# Altium schematic sheet [03] - IMU.SchDoc("[03] - IMU")
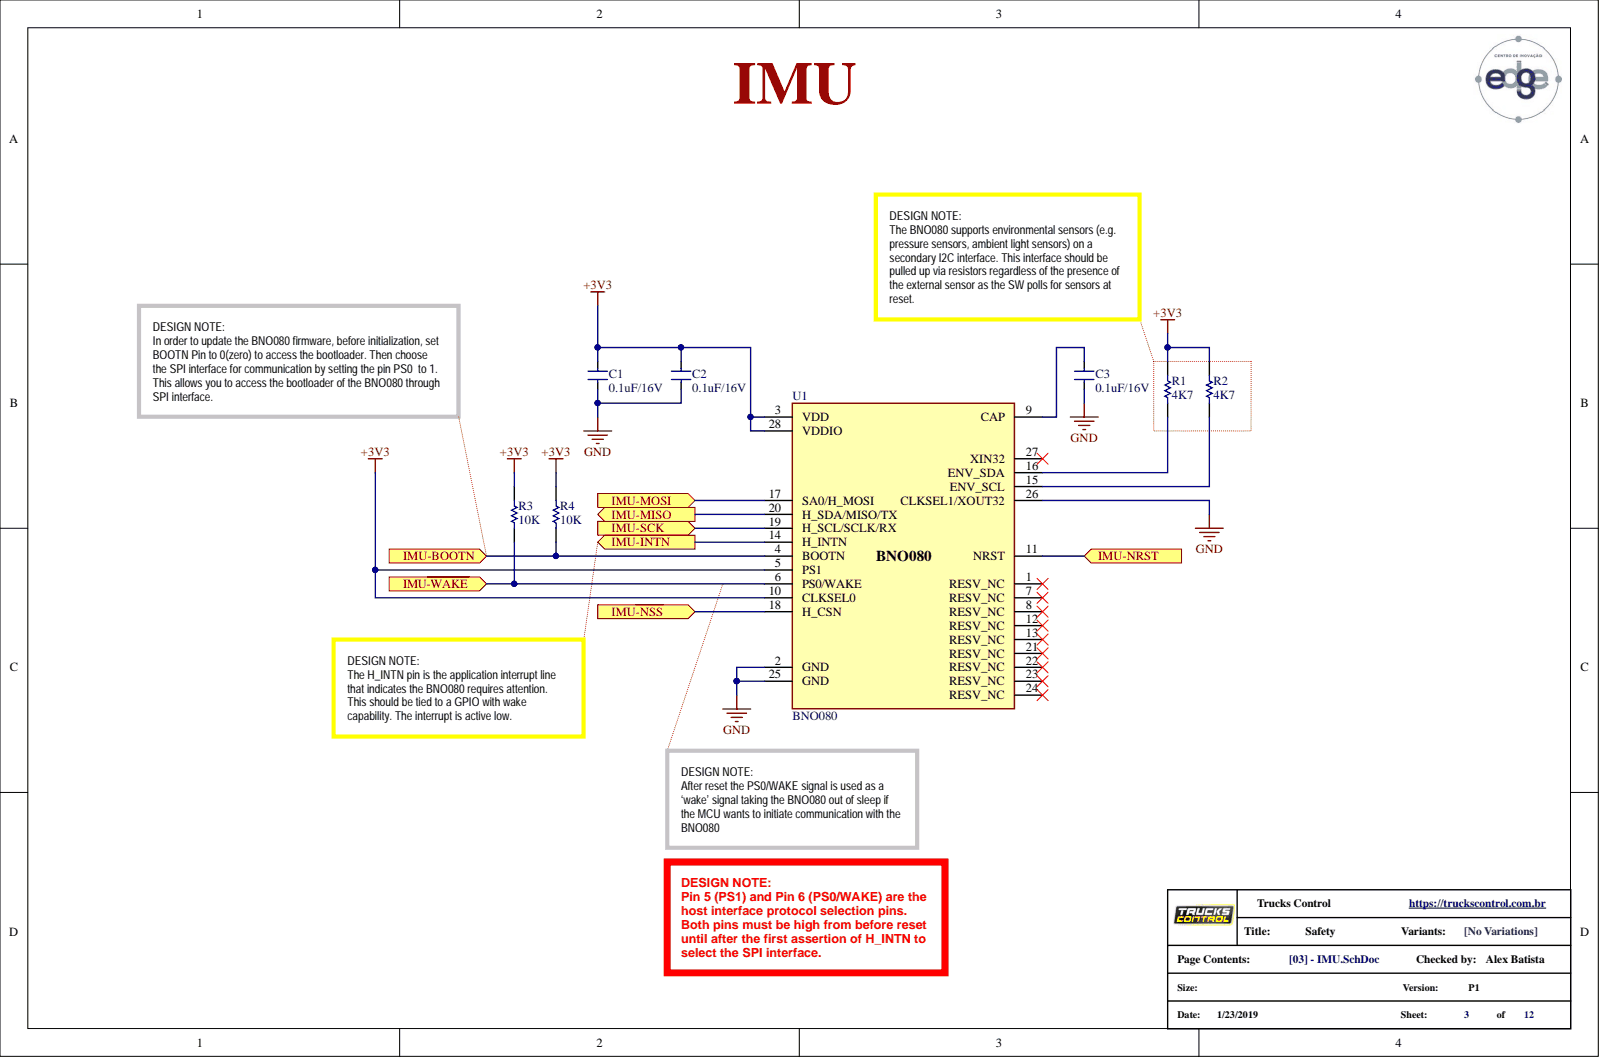
[diagram p0: [03] - IMU.SchDoc]

## Parts
C1  0.1uF/16V  [C0402]
C2  0.1uF/16V  [C0402]
C3  0.1uF/16V  [C0402]
R1  4K7  [R0402]
R2  4K7  [R0402]
R3  10K  [R0402]
R4  10K  [R0402]
U1  BNO080  [BNO080_LGA28]

## Ports
IMU-BOOTN, IMU-INTN, IMU-MISO, IMU-MOSI, IMU-NRST, IMU-SCK, IMU~{-NSS}, IMU~{-WAKE}

## Nets
+3V3: C1.2 C2.2 R1.2 R2.2 R3.1 R4.1 U1.3(VDD) U1.5(PS1) U1.10(CLKSEL0) U1.28(VDDIO)
GND: C1.1 C2.1 C3.1 U1.2(GND) U1.25(GND) U1.26(CLKSEL1/XOUT32)
IMU~{-NSS}: U1.18(H_CSN) ; port IMU~{-NSS}
IMU~{-WAKE}: R3.2 U1.6(PS0/WAKE) ; port IMU~{-WAKE}
IMU-BOOTN: R4.2 U1.4(BOOTN) ; port IMU-BOOTN
IMU-INTN: U1.14(H_INTN) ; port IMU-INTN
IMU-MISO: U1.20(H_SDA/MISO/TX) ; port IMU-MISO
IMU-MOSI: U1.17(SA0/H_MOSI) ; port IMU-MOSI
IMU-NRST: U1.11(NRST) ; port IMU-NRST
IMU-SCK: U1.19(H_SCL/SCLK/RX) ; port IMU-SCK
NetC3_2: C3.2 U1.9(CAP)
NetR1_1: R1.1 U1.16(ENV_SDA)
NetR2_1: R2.1 U1.15(ENV_SCL)

Not connected: U1 1(RESV_NC) 7(RESV_NC) 8(RESV_NC) 12(RESV_NC) 13(RESV_NC) 21(RESV_NC) 22(RESV_NC) 23(RESV_NC) 24(RESV_NC) 27(XIN32)

## Library data of the parts
C1-C3 = GCM155R71C104KA55D: 0.1UF 16V X7R 0402 CAP CER; Manufacturer: Murata; Tolerance: 10%; Capacitance: 100nF; Width: 0.02inch; Voltage Rating (DC): 16V; Voltage Rating: 16V; Voltage: 16V; Thickness: 0.022inch; Termination: SMD/SMT; Supplier Part Number 1: 81-GCM155R71C104KA5D; Supplier 1: Mouser; Stock 1: 3750168; REACH SVHC: No SVHC; Ratings: AEC-Q200; Radiation Hardening: No; Pricing 1: 1=0.1, 10=0.061, 50=0.061, 100=0.026, 1000=0.015, 10000=0.01 (USD); Packaging: Tape and Reel; Package Quantity: 1; Mount: Surface Mount; Min Operating Temperature: -55°C; Max Operating Temperature: 125°C; Manufacturer Part Number: GCM155R71C104KA55D; Length: 1mm; Lead Free: Lead Free; Height: 0.5mm; Dielectric: X7R; Depth: 0.5mm; Component Kind: Standard; Case Code (Metric): 1005; Case Code (Imperial): 0402; Case/Package: 0402; Library Name: tc-pcb-safety_V1I1.SchLib
R1 R2 = RC0402FR-074K7L: 4K7 ohm, 50 V,  1%,, 0402 [1005 Metric], 63 mW,  RC Series YAGEO (PHYCOMP)         RC0402FR-074K7L             SMD Chip Resistor, Thick Film; Voltage Rating (DC): 50V; Temperature Coefficient: 100ppm/°C; Resistance: 4.7kR; Power Rating: 62.5mW; Width: 0.5mm; Voltage Rating: 50V; Tolerance: 1%; Termination: SMD/SMT; Supplier Part Number 1: 603-RC0402FR-074K7L; Supplier 1: Mouser; Stock 1: 1734729; REACH SVHC: No SVHC; Pricing 1: 1=0.1, 10=0.012, 50=0.012, 100=0.004, 1000=0.003, 10000=0.003 (USD); Packaging: Tape and Reel; Number of Pins: 2; Min Operating Temperature: -55°C; Military Standard: Not; Max Operating Temperature: 155°C; Manufacturer Part Number: RC0402FR-074K7L; Manufacturer: Yageo; Length: 1mm; Lead Free: Lead Free; Height: 0.32mm; Depth: 0.5mm; Composition: Thick Film; ComponentLink4URL: http://www.farnell.com/datasheets/1686128.pdf; ComponentLink4Description: http://www.farnell.com/datasheets/1686128.pdf; ComponentLink3URL: https://www.verical.com/server-webapp/html/getDatasheet?partID=328119; ComponentLink3Description: https://www.verical.com/server-webapp/html/getDatasheet?partID=328119; ComponentLink2URL: http://www.farnell.com/datasheets/1018594.pdf; ComponentLink2Description: http://www.farnell.com/datasheets/1018594.pdf; ComponentLink1URL: http://documents.rs-components.com/techlib://itc_doclist_GB.htm?stockno=6184558; ComponentLink1Description: http://documents.rs-components.com/techlib://itc_doclist_GB.htm?stockno=6184558; Component Kind: Standard; Case Code (Metric): 1005; Case Code (Imperial): 0402; Case/Package: 0402; Library Name: tc-pcb-safety_V1I1.SchLib
R3 R4 = RC0402JR-7D10KL: 10K OHM 5% 1/16W 0402; Manufacturer: Yageo; Supplier Part Number 1: 603-RC0402JR-7D10KL; Supplier 1: Mouser; Stock 1: 178452; Pricing 1: 1=0.1, 10=0.01, 50=0.01, 100=0.004, 1000=0.003, 10000=0.002 (USD); Manufacturer Part Number: RC0402JR-7D10KL; Component Kind: Standard; Library Name: tc-pcb-safety_V1I1.SchLib
U1 = BNO080: IMU - Accelerometer, Gyroscope, Magnetometer, 9 Axis Sensor I²C, SPI, UART Output; Manufacturer: Hillcrest Laboratories; Supplier Part Number 1: 1888-1000-1-ND; Supplier 1: Digi-Key; Stock 1: 8454; Pricing 1: 1 = 13.48000, 5 = 11.82600, 10 = 10.64300, 25 = 9.46000, 50 = 8.75060, 100 = 7.56800, 500 = 7.09500, 1000 = 6.62200 (USD); Manufacturer Part Number: BNO080; Component Kind: Standard; Library Name: tc-pcb-safety_V1I1.SchLib
